# Altium schematic sheet GREEN_CIRCUITRY.SchDoc(GREEN_CIRCUITRY)
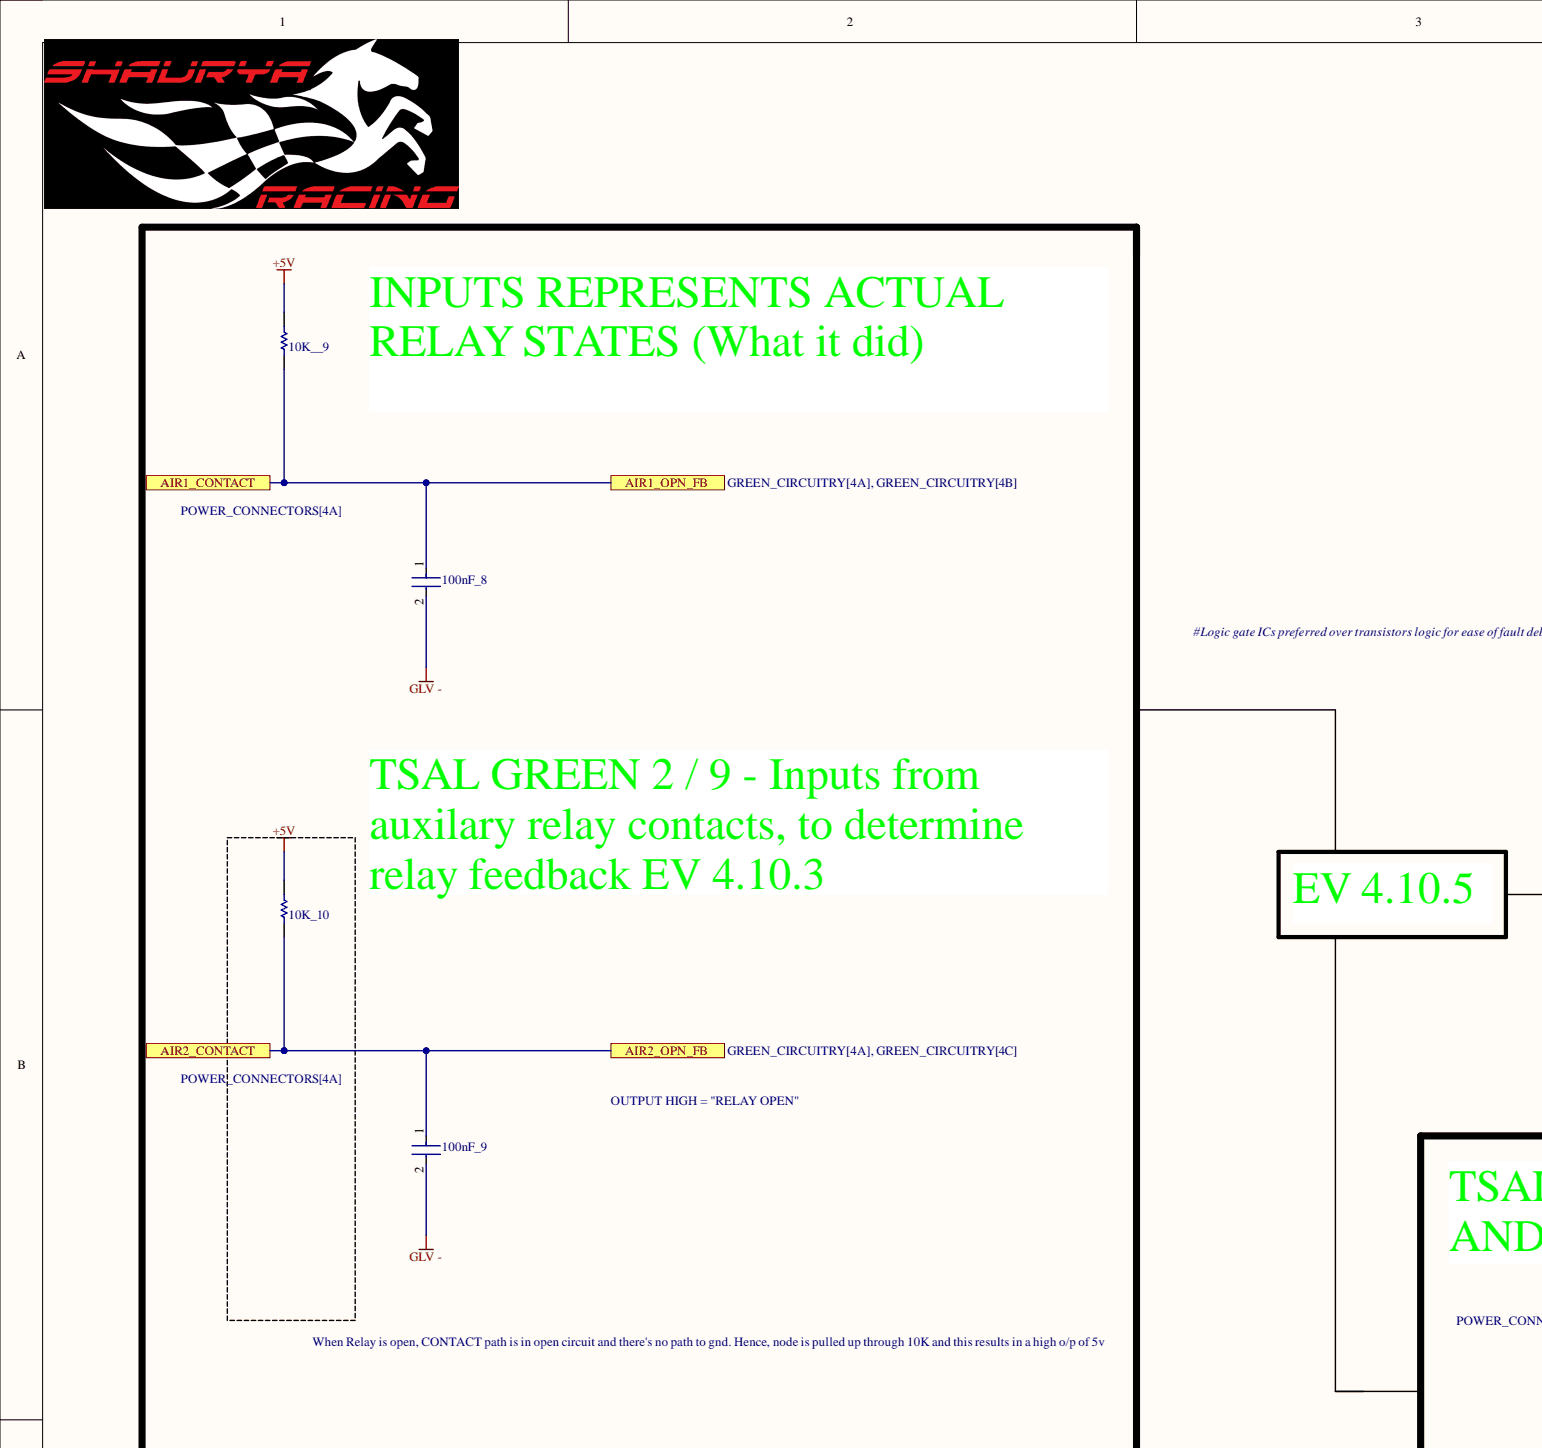
[diagram p0: GREEN_CIRCUITRY.SchDoc, top left]
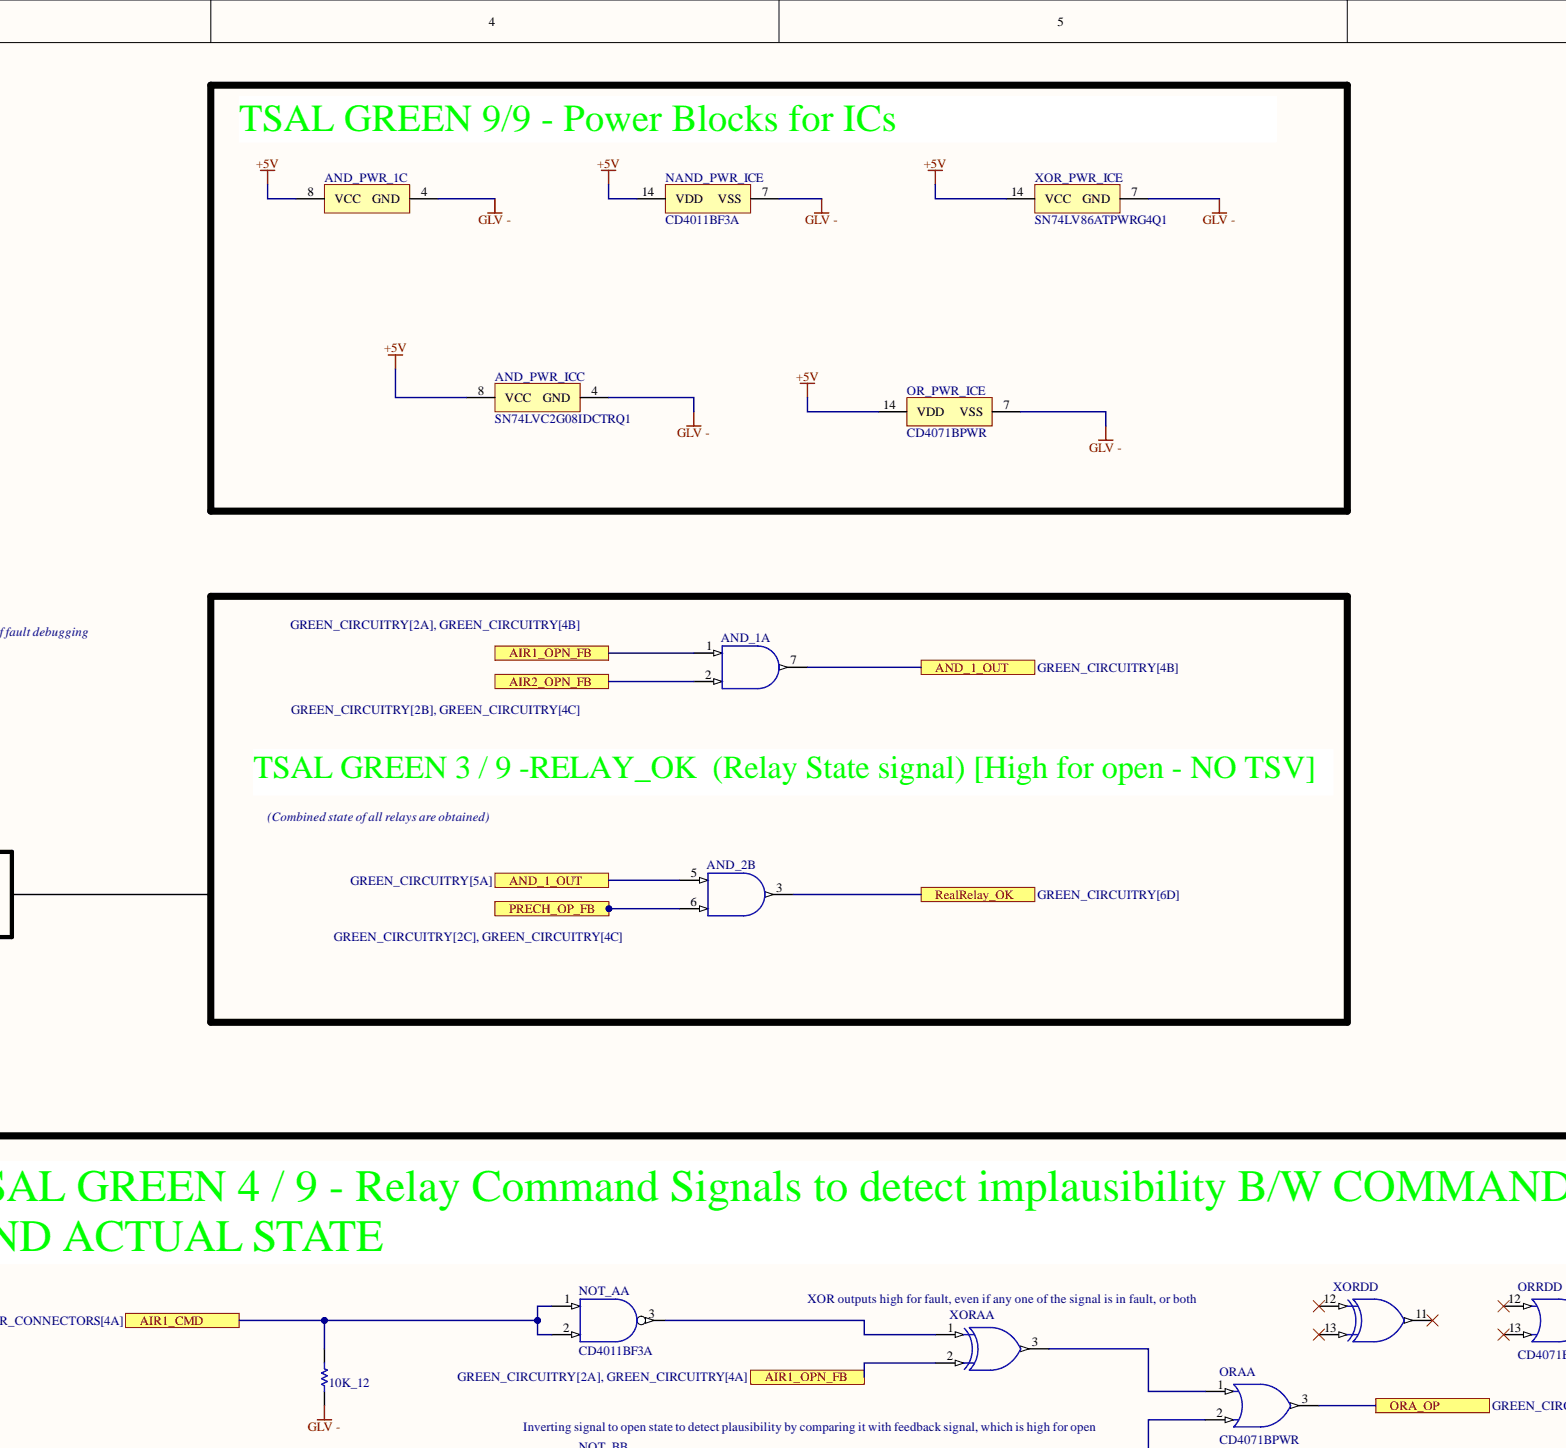
[diagram p1: GREEN_CIRCUITRY.SchDoc, top centre]
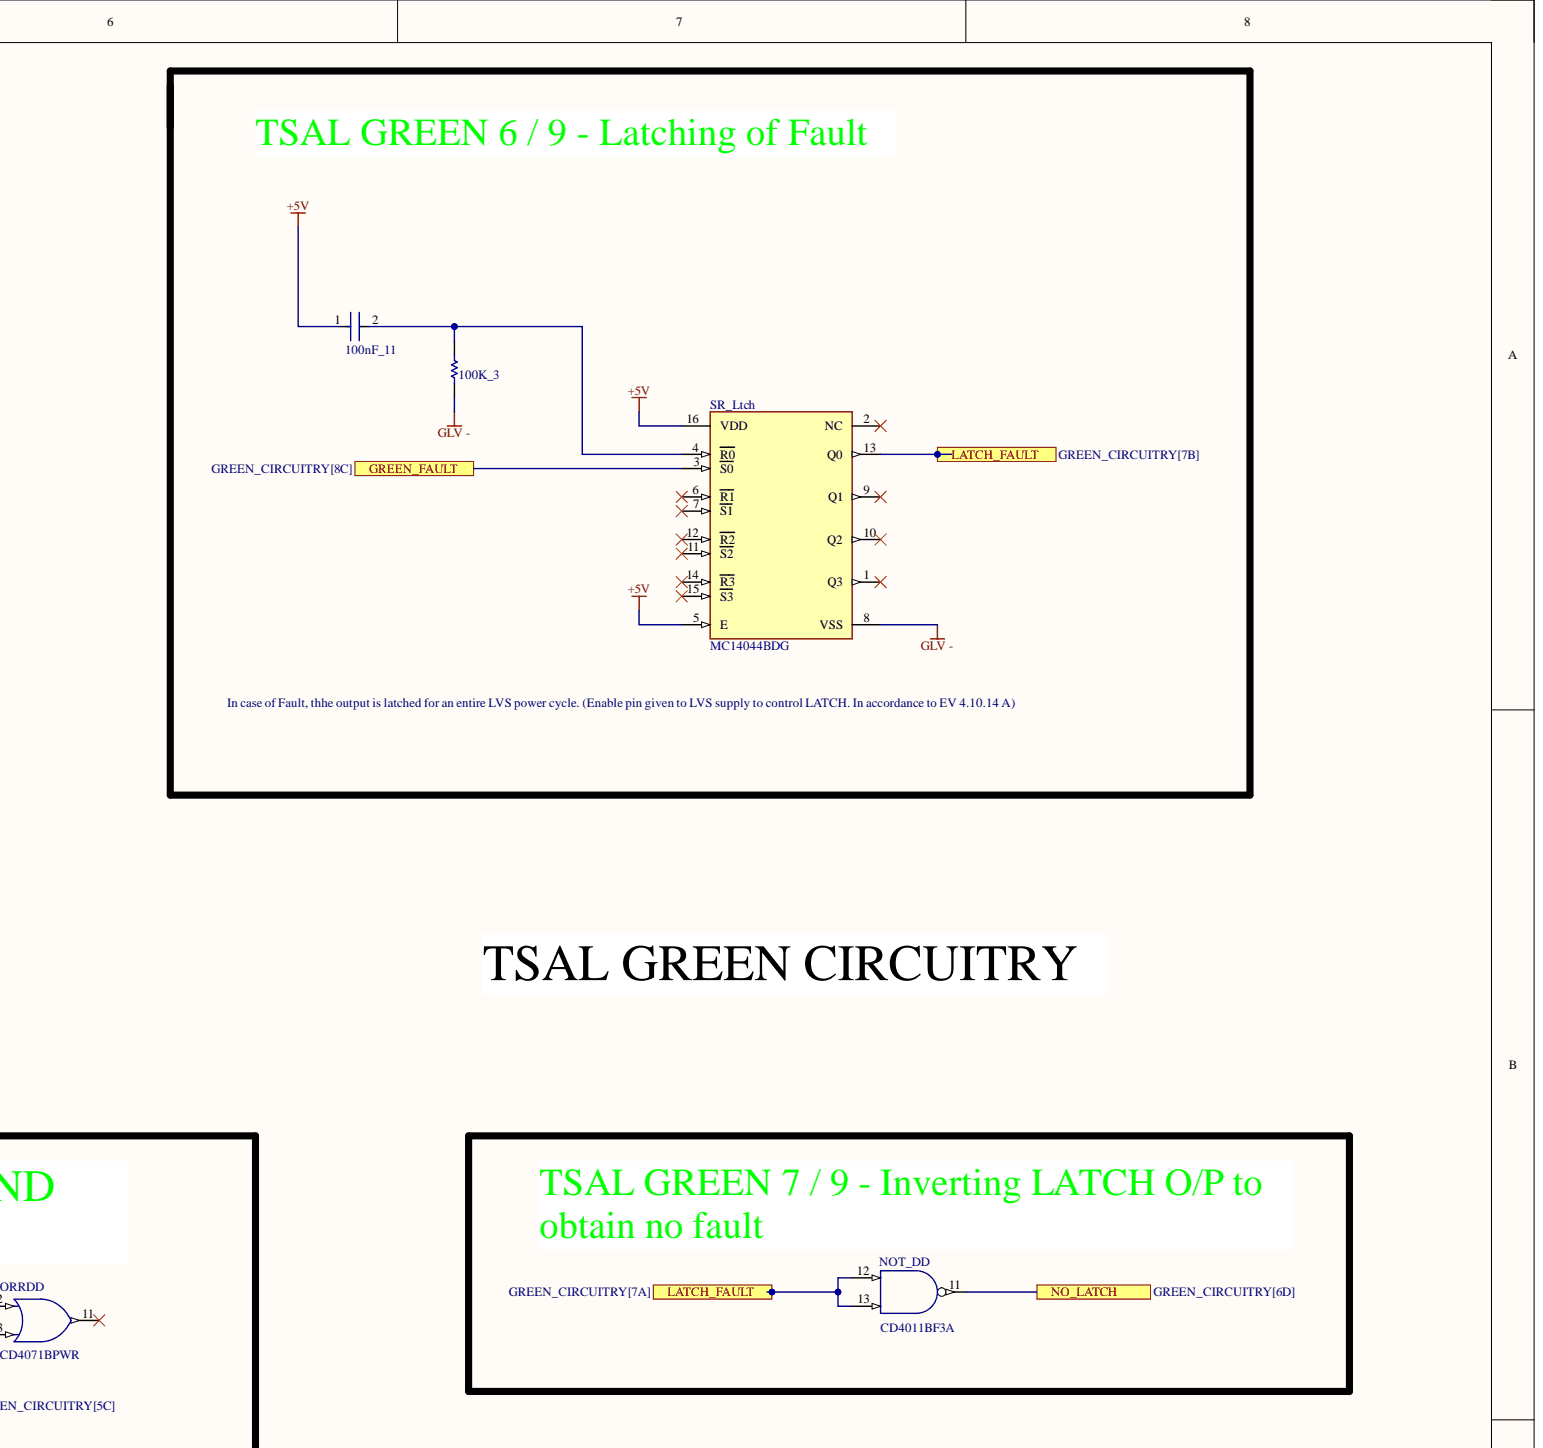
[diagram p2: GREEN_CIRCUITRY.SchDoc, top right]
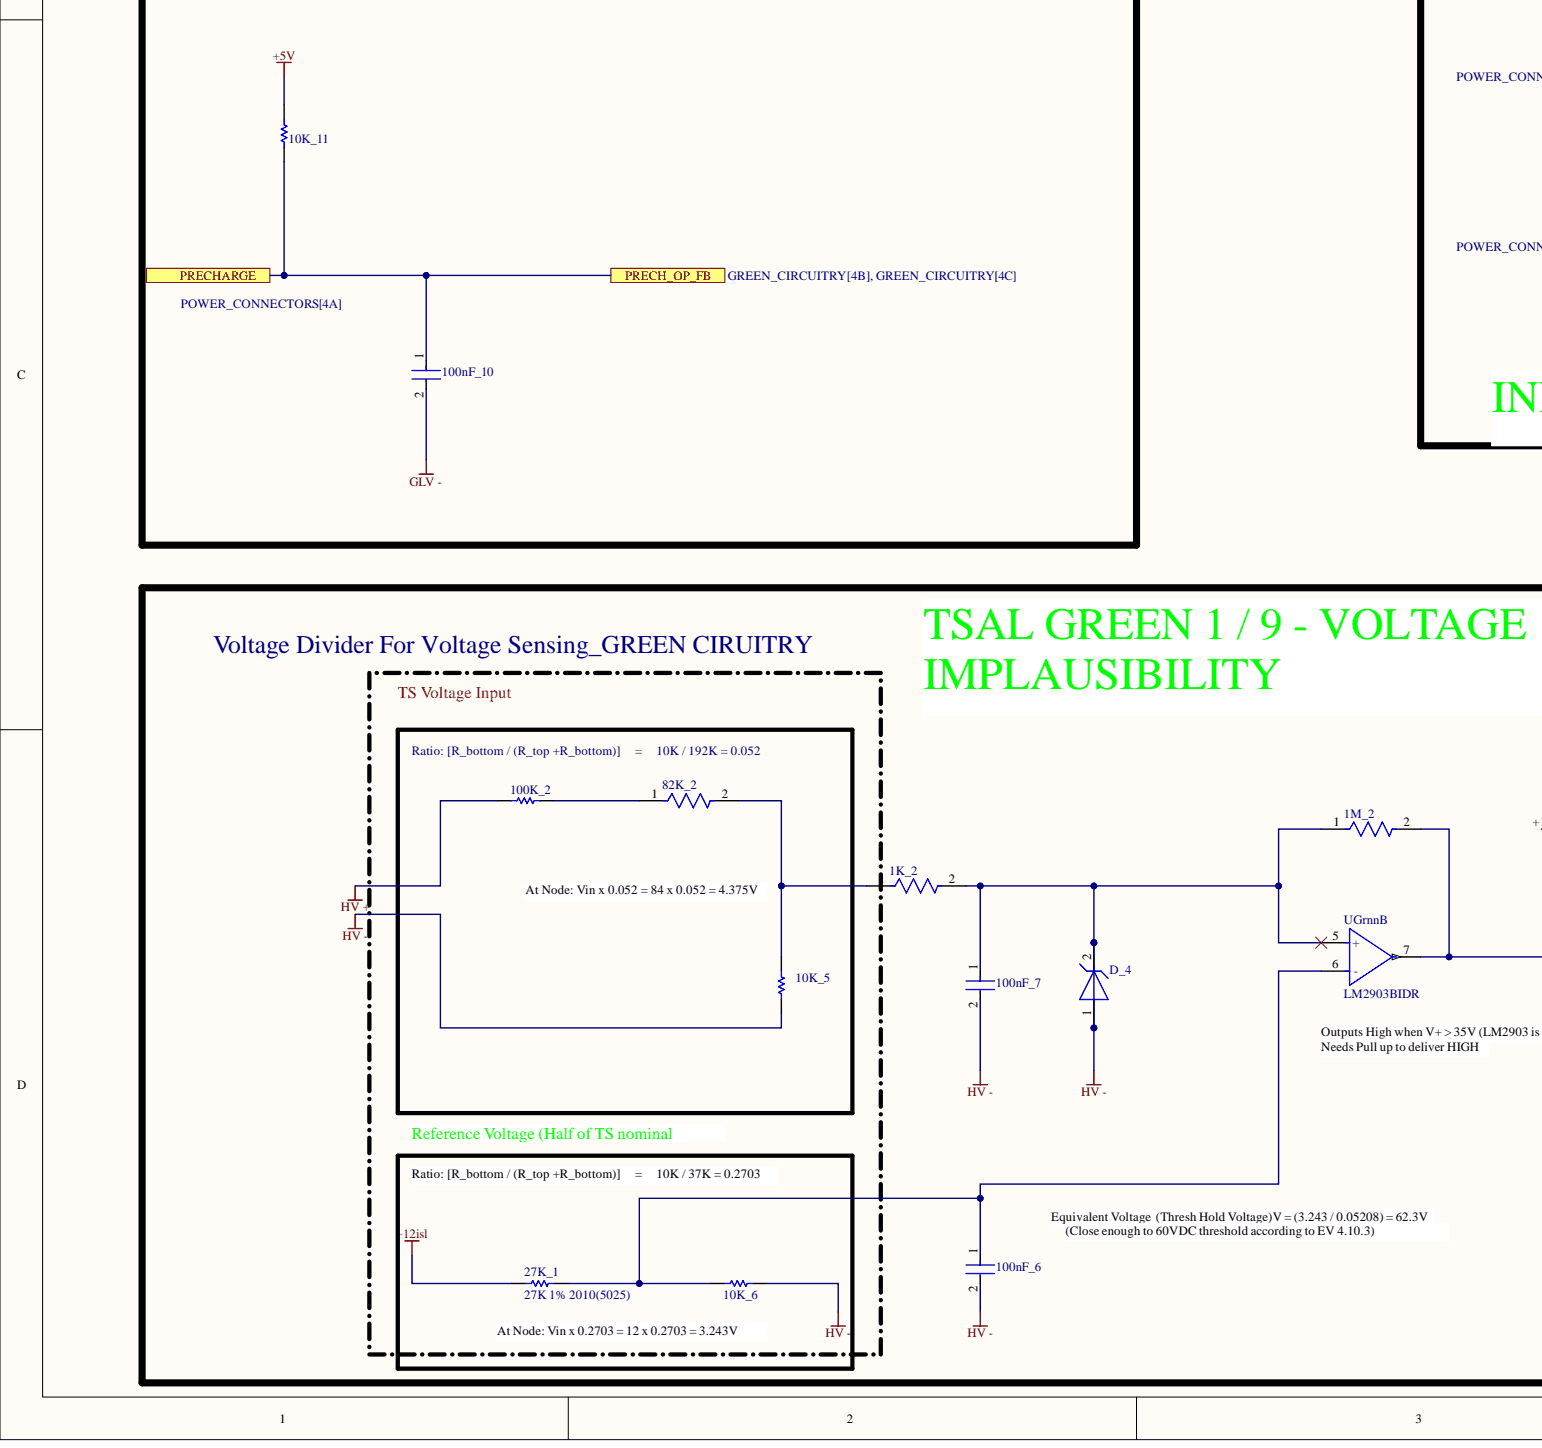
[diagram p3: GREEN_CIRCUITRY.SchDoc, bottom left]
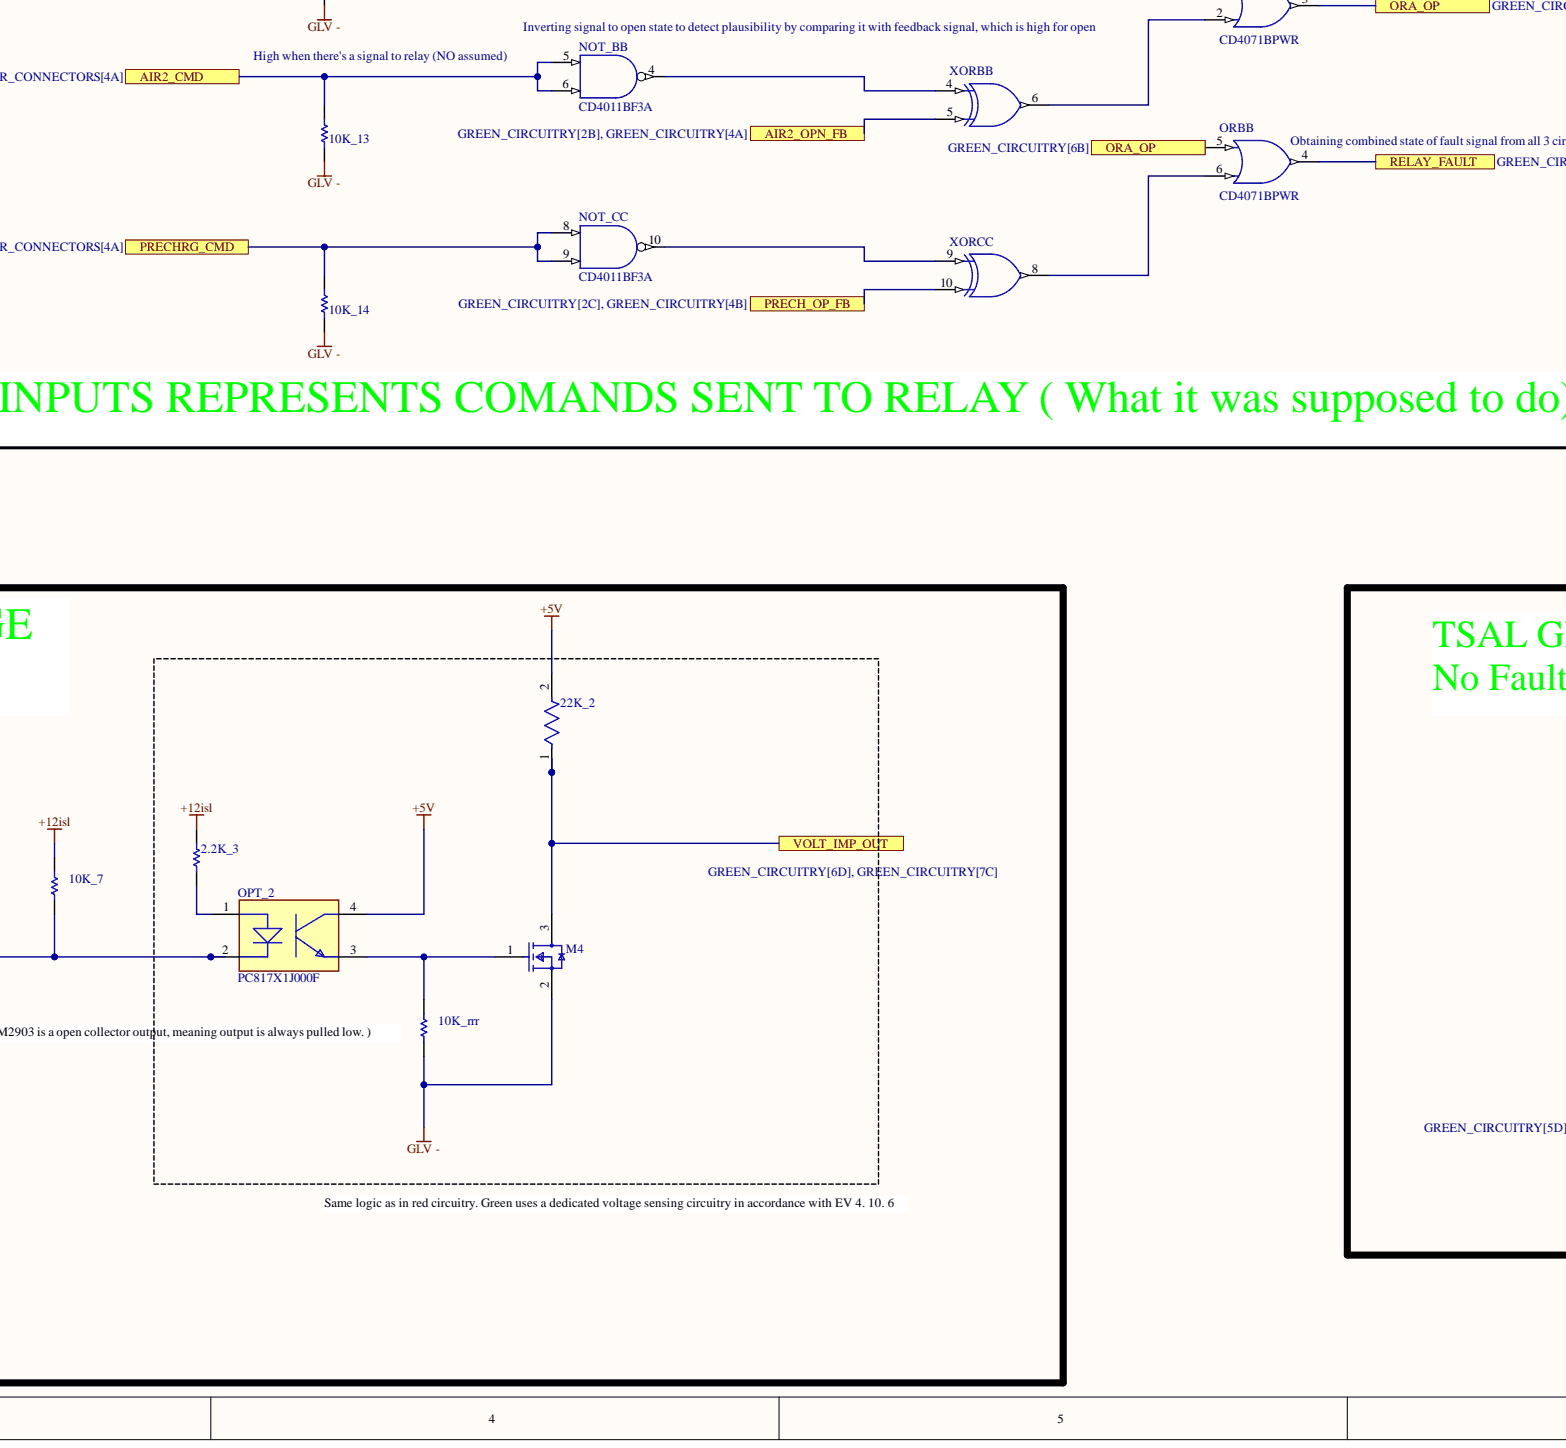
[diagram p4: GREEN_CIRCUITRY.SchDoc, bottom centre]
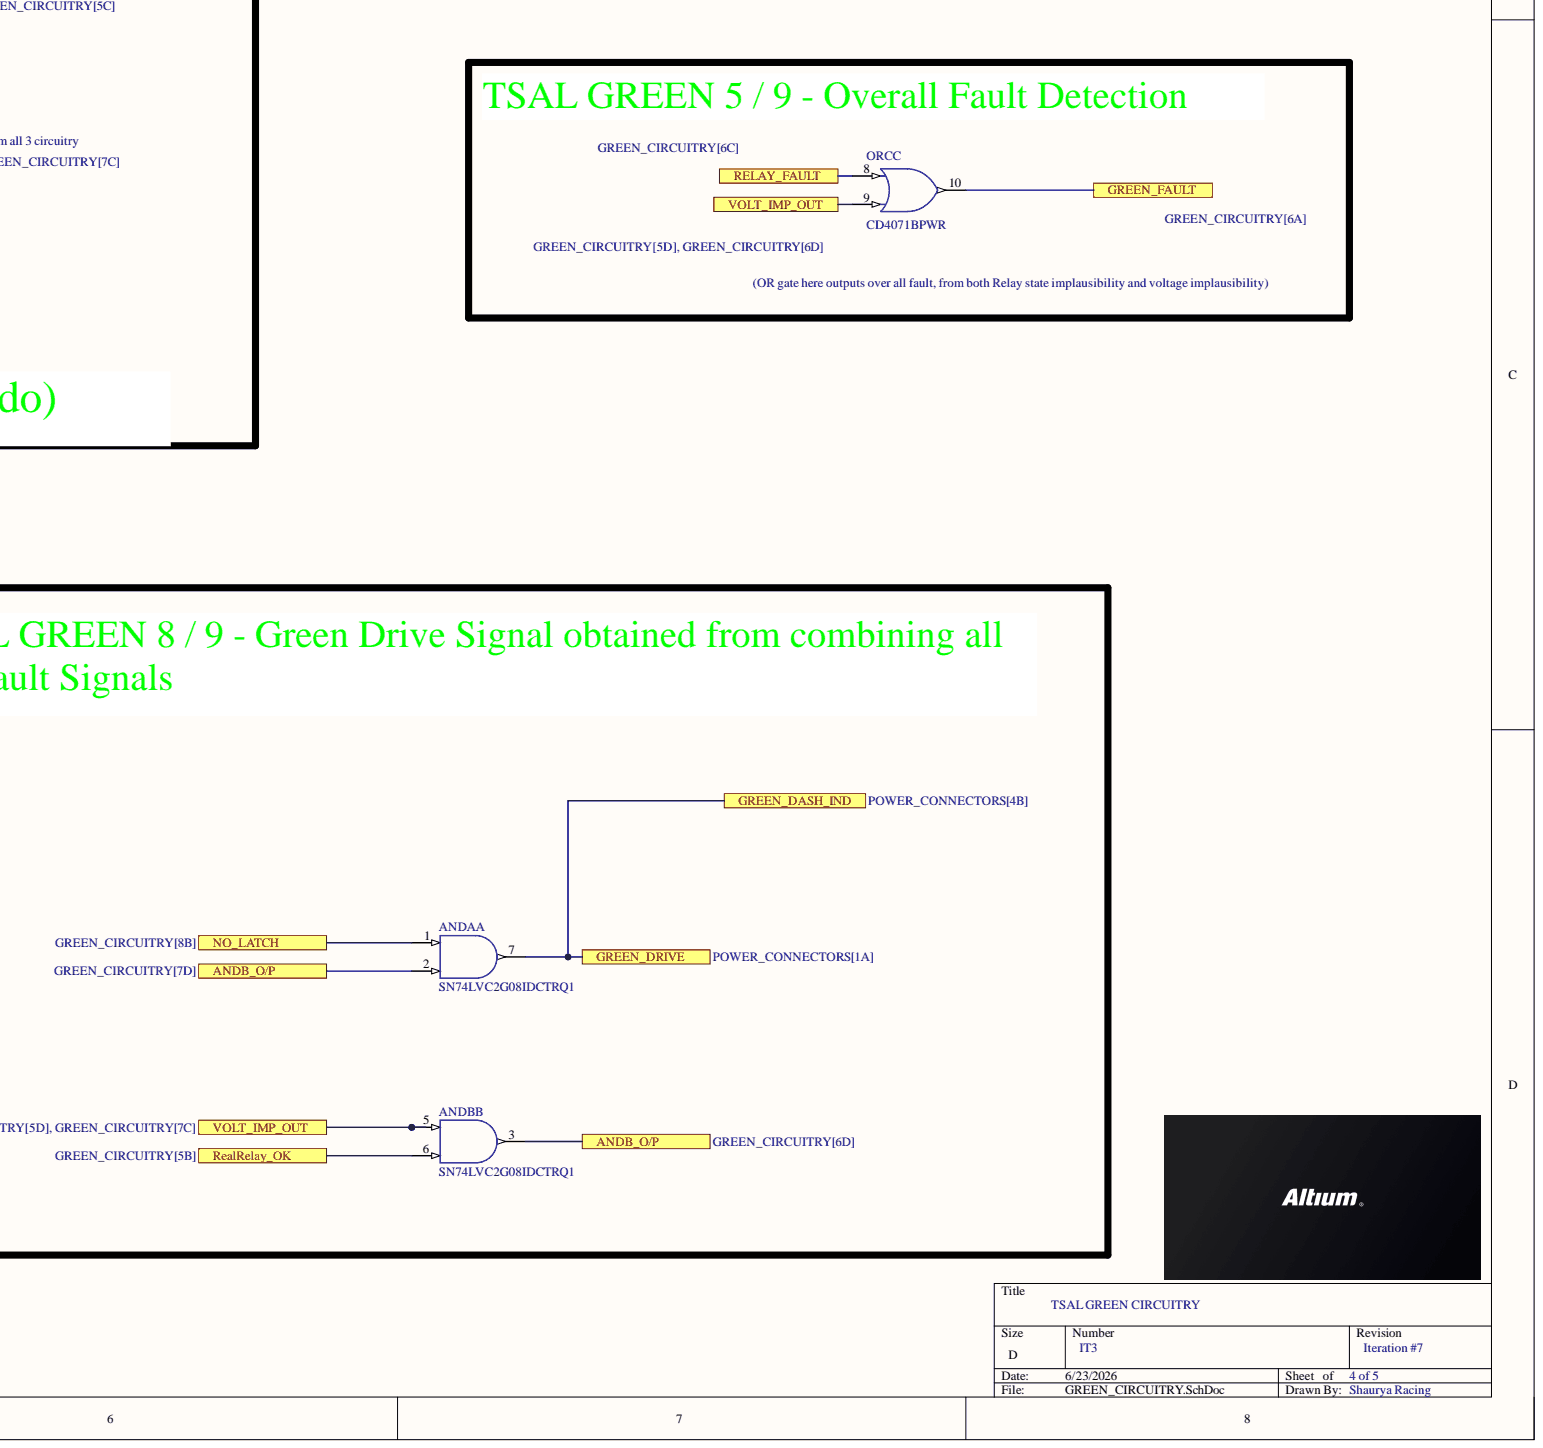
[diagram p5: GREEN_CIRCUITRY.SchDoc, bottom right]

## Parts
1K_2  CRCW08051K00JNEC  (value not drawn)
1M_2  MCU08050D1004BP500  (value not drawn)
2.2K_3  2K2 5% 0402(1005)  (value not drawn)
10K_5  TNPW121010K0BEEN  (value not drawn)
10K_6  TNPW121010K0BEEN  (value not drawn)
10K_7  TNPW121010K0BEEN  (value not drawn)
10K_10  TNPW121010K0BEEN  (value not drawn)
10K_11  TNPW121010K0BEEN  (value not drawn)
10K_12  TNPW121010K0BEEN  (value not drawn)
10K_13  TNPW121010K0BEEN  (value not drawn)
10K_14  TNPW121010K0BEEN  (value not drawn)
10K__9  TNPW121010K0BEEN  (value not drawn)
10K_rrr  TNPW121010K0BEEN  (value not drawn)
22K_2  CRCW121822K0FKEK  (value not drawn)
27K_1  27K 1% 2010(5025)
82K_2  CRCW121082K0FKEA  (value not drawn)
100K_2  TNPW0805100KDEEA  (value not drawn)
100K_3  TNPW0805100KDEEA  (value not drawn)
100nF_6  C0805C104K5RACTU  (value not drawn)
100nF_7  C0805C104K5RACTU  (value not drawn)
100nF_8  C0805C104K5RACTU  (value not drawn)
100nF_9  C0805C104K5RACTU  (value not drawn)
100nF_10  C0805C104K5RACTU  (value not drawn)
100nF_11  C0805C104K5RACTU  (value not drawn)
AND_1  SN74LVC2G08IDCTRQ1  (part A)
AND_2  SN74LVC2G08IDCTRQ1  (part B)
AND_PWR_1  SN74LVC2G08IDCTRQ1  (part C)
AND_PWR_IC  SN74LVC2G08IDCTRQ1  (part C)
ANDA  SN74LVC2G08IDCTRQ1  (part A)
ANDB  SN74LVC2G08IDCTRQ1  (part B)
D_4  BZD27C5V1P-E3-08  (value not drawn)
M4  2N7002H6327XTSA2  (value not drawn)
NAND_PWR_IC  CD4011BF3A  (part E)
NOT_A  CD4011BF3A  (part A)
NOT_B  CD4011BF3A  (part B)
NOT_C  CD4011BF3A  (part C)
NOT_D  CD4011BF3A  (part D)
OPT_2  PC817X1J000F
OR_PWR_IC  CD4071BPWR  (part E)
ORA  CD4071BPWR  (part A)
ORB  CD4071BPWR  (part B)
ORC  CD4071BPWR  (part C)
ORRD  CD4071BPWR  (part D)
SR_Ltch  MC14044BDG
UGrnn  LM2903BIDR  (part B)
XOR_PWR_IC  SN74LV86ATPWRG4Q1  (part E)
XORA  SN74LV86ATPWRG4Q1  (part A)
XORB  SN74LV86ATPWRG4Q1  (part B)
XORC  SN74LV86ATPWRG4Q1  (part C)
XORD  SN74LV86ATPWRG4Q1  (part D)

## Ports
AIR1_CMD, AIR1_CONTACT, AIR1_OPN_FB, AIR2_CMD, AIR2_CONTACT, AIR2_OPN_FB, AND_1_OUT, ANDB_O/P, GREEN_DASH_IND, GREEN_DRIVE, GREEN_FAULT, LATCH_FAULT, NO_LATCH, ORA_OP, PRECH_OP_FB, PRECHARGE, PRECHRG_CMD, RealRelay_OK, RELAY_FAULT, VOLT_IMP_OUT

## Nets
+5V: 10K_10.1 10K_11.1 10K__9.1 22K_2.2 100nF_11.1 AND_PWR_1.8(VCC) AND_PWR_IC.8(VCC) NAND_PWR_IC.14(VDD) OPT_2.4 OR_PWR_IC.14(VDD) SR_Ltch.5(E) SR_Ltch.16(VDD) XOR_PWR_IC.14(VCC)
+12isl: 2.2K_3.2 10K_7.2 27K_1.1
GLV -: 10K_12.2 10K_13.2 10K_14.2 10K_rrr.1 100K_3.1 100nF_8.2 100nF_9.2 100nF_10.2 AND_PWR_1.4(GND) AND_PWR_IC.4(GND) M4.2 NAND_PWR_IC.7(VSS) OR_PWR_IC.7(VSS) SR_Ltch.8(VSS) XOR_PWR_IC.7(GND)
HV +: 100K_2.1
HV -: 1K_2.2 1M_2.1 10K_5.1 10K_6.2 100nF_6.2 100nF_7.1 100nF_7.2 D_4.1 D_4.2 UGrnn.5(+)
AIR1_CMD: 10K_12.1 NOT_A.1 NOT_A.2 ; port AIR1_CMD
AIR1_CONTACT: 10K__9.2 100nF_8.1 AND_1.1 XORA.2 ; port AIR1_CONTACT, port AIR1_OPN_FB
AIR2_CMD: 10K_13.1 NOT_B.5 NOT_B.6 ; port AIR2_CMD
AIR2_CONTACT: 10K_10.2 100nF_9.1 AND_1.2 XORB.5 ; port AIR2_CONTACT, port AIR2_OPN_FB
AND_1_OUT: AND_1.7 AND_2.5 ; port AND_1_OUT
ANDB_O/P: ANDA.2 ANDB.3 ; port ANDB_O/P
GREEN_DASH_IND: ANDA.7 ; port GREEN_DASH_IND, port GREEN_DRIVE
GREEN_FAULT: ORC.10 SR_Ltch.3(S0) ; port GREEN_FAULT
LATCH_FAULT: NOT_D.12 NOT_D.13 SR_Ltch.13(Q0) ; port LATCH_FAULT
NO_LATCH: ANDA.1 NOT_D.11 ; port NO_LATCH
ORA_OP: ORA.3 ORB.5 ; port ORA_OP
PRECHARGE: 10K_11.2 100nF_10.1 AND_2.6 XORC.10 ; port PRECHARGE, port PRECH_OP_FB
PRECHRG_CMD: 10K_14.1 NOT_C.8 NOT_C.9 ; port PRECHRG_CMD
RealRelay_OK: AND_2.3 ANDB.6 ; port RealRelay_OK
RELAY_FAULT: ORB.4 ORC.8 ; port RELAY_FAULT
VOLT_IMP_OUT: 22K_2.1 ANDB.5 M4.3 ORC.9 ; port VOLT_IMP_OUT
Net1K_2_1: 1K_2.1 10K_5.2 82K_2.2
Net1M_2_2: 1M_2.2 10K_7.1 OPT_2.2 UGrnn.7
Net2.2K_3_1: 2.2K_3.1 OPT_2.1
Net10K_6_1: 10K_6.1 27K_1.2 100nF_6.1 UGrnn.6(-)
Net10K_rrr_2: 10K_rrr.2 M4.1 OPT_2.3
Net82K_2_1: 82K_2.1 100K_2.2
Net100K_3_2: 100K_3.2 100nF_11.2 SR_Ltch.4(R0)
NetNOT_A_3: NOT_A.3 XORA.1
NetNOT_B_4: NOT_B.4 XORB.4
NetNOT_C_10: NOT_C.10 XORC.9
NetORA_1: ORA.1 XORA.3
NetORA_2: ORA.2 XORB.6
NetORB_6: ORB.6 XORC.8

Not connected: ORRD 11 12 13; SR_Ltch 1(Q3) 2(NC) 6(R1) 7(S1) 9(Q1) 10(Q2) 11(S2) 12(R2) 14(R3) 15(S3); XORD 11 12 13

## Library data of the parts
1K_2 = CMP-02407-005778-1: RES Thick Film, 1kΩ, 5%, 0.125W, 200ppm/°C, 0805; Max Power Dissipation: 125mW; Resistance: 1kR; Case/Package: 0805; Component Kind: Standard; Composition: Thick Film; Contact Plating: Tin; Features: Automotive AEC-Q200; Height: 0.02inch; Lead Free: Lead Free; Length: 0.079inch; Library Name: Altium Content Vault; Max Operating Temperature: 155°C; Min Operating Temperature: -55°C; Number of Terminations: 2; Package Quantity: 20000; Packaging: Tape and Reel; Radiation Hardening: No; Temperature Coefficient: 200ppm/°C; Tolerance: 5%; Width: 0.049inch
1M_2 = CMP-02407-001361-1: RES 1.05K OHM 0.5% 1/5W 0805; Resistance: 1MR; Tolerance: 0.1%; Case/Package: 0805; Component Kind: Standard; Height: 450um; Lead Free: Lead Free; Length: 2mm; Library Name: Altium Content Vault; Width: 1.25mm
2.2K_3 = CMP-1011-00082-1: 2K2 0.063W 5% 0402 (1005 Metric)  SMD; Case-EIA: 0402; Case-Metric: 1005; Value: 2200.00 Ohm; Component Kind: Standard; Library Name: Altium Content Vault; Power: 0.063 W; Technology: SMT; Tolerance: 5 %
10K_5-10K_7 10K_10-10K_14 10K__9 10K_rrr = CMP-2005-00857-1: Manufacturer: Vishay Dale; Component Kind: Standard; Library Name: Altium Content Vault; Part Number: TNPW121010K0BEEN
22K_2 = CMP-1016-00638-2: RES Thick Film, 22kΩ, 1%, 1W, 100ppm/°C, 1218; Resistance: 22000R; Component Kind: Standard; Lead Free: Lead Free; Library Name: Altium Content Vault; Power Rating: 1W; Tolerance: 1%
27K_1 = CMP-1017-00670-1: 27K 0.75W 1% 2010 (5025 Metric)  SMD; Case-EIA: 2010; Case-Metric: 5025; Value: 27000.00 Ohm; Component Kind: Standard; Library Name: Altium Content Vault; Power: 0.75 W; Technology: SMT; Tolerance: 1 %
82K_2 = CMP-1015-00726-2: RES SMD 205K OHM 1% 1/2W 2203; Resistance: 82kR; Temperature Coefficient: 100ppm/°C; Voltage Rating (DC): 200V; Case/Package: 1210; Component Kind: Standard; Composition: Thick Film; Contact Plating: Tin; Height: 550um; Lead Free: Lead Free; Length: 3.2mm; Library Name: Altium Content Vault; Max Operating Temperature: 155°C; Min Operating Temperature: -55°C; Packaging: Tape and Reel; Radiation Hardening: No; Tolerance: 1%; Width: 2.5mm
100K_2 100K_3 = CMP-2001-04708-1: Manufacturer: Vishay Dale; Component Kind: Standard; Library Name: Altium Content Vault; Part Number: TNPW0805100KDEEA
100nF_6-100nF_11 = CMP-2007-00279-2: CAP CER 0.1UF 50V X7R 0805; Manufacturer: KEMET; Capacitance: 0.10 μF; Temperature Coefficient: 30ppm/°C; Tolerance: 0.1; Value: 0.10 μF; Automotive: No; Category: Cap; Component Kind: Standard; ComponentLink2Description: Datasheet; ComponentLink2URL: https://content.kemet.com/datasheets/KEM_C1003_C0G_SMD.pdf; Device Class L1: Passive Components; Device Class L2: Capacitors; Device Class L3: Ceramic Capacitors; Digikey Description: CAP CER 0.1UF 50V X7R 0805; Height: 0.88mm; Ipc Land Pattern Name: CAPC200125X78; Lead Free: Yes; Library Name: Altium Content Vault; Manufacturer Part Number: C0805C104K5RACTU; Material: Ceramic; Mouser Description: Cap Ceramic 0.1uF 50V X7R 10% SMD 2.0 x 1.2 mm 125 C Paper T/R; Octopart: https://octopart.com/c0805c104k5ractu-kemet-143926; Package: C0805C-DN; RoHS: TRUE; Temperature: -55°C to +125°C; Temperature Characteristic: X7R; Temperature Range High: +125°C; Temperature Range Low: -55°C; Voltage Rating: 50 V
AND_1 AND_2 AND_PWR_1 AND_PWR_IC ANDA ANDB = CMP-0859-00543-3: Automotive Catalog Dual 2-Input Positive-AND Gate, DCT0008A, LARGE T&R; Generic Part Number: SN74LVC2G08-Q1; Manufacturer: Texas Instruments; 3-State Output: No; Bits(#): 2; F @ Nom Voltage(Max)(Mhz): 150; ICC @ Nom Voltage(Max)(mA): 0.01; Input Type: CMOS/TTL; Logic: True; Output Drive (IOL/IOH)(Max)(mA): 32/-32; Output Type: CMOS; Technology Family: LVC; tpd @ Nom Voltage(Max)(ns): 9,5.1,4.7,3.8; VCC(Max)(V): 5.5; VCC(Min)(V): 1.65; Voltage(Nom)(V): 1.8,2.5,3.3,5; Component Kind: Standard; DatasheetVersion: SCES557D; Library Name: Altium Content Vault; Operating Temperature Range(C): -40 to 85; PackageReference: DCT0008A; Rating: Automotive; Schmitt Trigger: No; Sub-Family: AND Gate
D_4 = CMP-02541-000027-1: DIODE ZENER 5.1V 800MW DO219AB; Element Configuration: Single; ESD Protection: Yes; Forward Voltage: 1.2V; Impedance: 6R; Max Reverse Current: 5uA; Test Current: 100mA; Tolerance: 6%; Voltage Tolerance: 5%; Breakdown Voltage: 5.1V; Case/Package: DO-219AB; Component Kind: Standard; Contact Plating: Tin; Height: 1.08mm; Lead Free: Lead Free; Length: 2.9mm; Library Name: Altium Content Vault; Max Operating Temperature: 175°C; Max Power Dissipation: 800mW; Max Reverse Leakage Current: 5uA; Min Operating Temperature: -65°C; Mount: Surface Mount; Number of Pins: 2; Package Quantity: 3000; Packaging: Tape and Reel; RoHS Compliant: Yes; Series: Automotive, AEC-Q101, BZD27C; Width: 1.9mm; Zener Current: 5uA; Zener Voltage: 5.1V
M4 = CMP-32901-000180-1: MOSFET N-CH 60V 0.3A SOT23; Continuous Drain Current (ID): 300mA; Gate to Source Voltage (Vgs): 20V; Input Capacitance: 20pF; Max Power Dissipation: 500mW; Rds On Max: 3R; Rise Time: 3.3ns; Case/Package: SOT-23; Component Kind: Standard; Drain to Source Voltage (Vdss): 60V; Halogen Free: Halogen Free; Lead Free: Lead Free; Library Name: Altium Content Vault; Max Dual Supply Voltage: 60V; Max Operating Temperature: 150°C; Min Operating Temperature: -55°C; Mount: Surface Mount; Number of Pins: 3; Packaging: Tape and Reel
NAND_PWR_IC NOT_A NOT_B NOT_C NOT_D = CMP-1627-00341-2: CMOS Quad 2-Input NAND Gate, J0014A, TUBE; Generic Part Number: CD4011B-MIL; Manufacturer: Texas Instruments; Bits(#): 4; F @ Nom Voltage(Max)(Mhz): 8; ICC @ Nom Voltage(Max)(mA): 0.015; Output Drive (IOL/IOH)(Max)(mA): 1.5/-1.5; Rating: Military; Schmitt Trigger: No; Technology Family: CD4000; VCC(Max)(V): 18; VCC(Min)(V): 3; Voltage(Nom)(V): 10; Component Kind: Standard; DatasheetVersion: SCHS021D; Input Type: CMOS; Library Name: Altium Content Vault; Operating Temperature Range(C): -55 to 125; Output Type: CMOS; PackageReference: J0014A
OPT_2 = CMP-65434-000003-1: OPTOISOLATOR 5KV TRANS 4DIP; Collector Emitter Breakdown Voltage: 80V; Collector Emitter Saturation Voltage: 200mV; Current Transfer Ratio: 600%; Fall Time: 18000ns; Forward Current: 50mA; Forward Voltage: 1.4V; Input Current: 20mA; Max Power Dissipation: 200mW; Number of Channels: 1; Reverse Breakdown Voltage: 6V; Case/Package: DIP; Collector Emitter Voltage (VCEO): 80V; Component Kind: Standard; Isolation Voltage: 5kV; Library Name: Altium Content Vault; Max Collector Current: 50mA; Max Input Current: 50mA; Max Operating Temperature: 100°C; Min Operating Temperature: -30°C; Mount: Through Hole; Number of Elements: 1; Number of Pins: 4; Output Current per Channel: 50mA; Output Voltage: 80V; Package Quantity: 2000; Packaging: Sleeve; Power Dissipation: 200mW; Radiation Hardening: No; Rise Time: 18000ns
OR_PWR_IC ORA ORB ORC ORRD = CMP-1573-00201-3: CMOS Quad 2-Input OR Gate, PW0014A, LARGE T&R; Manufacturer: Texas Instruments; Bits(#): 4; F @ Nom Voltage(Max)(Mhz): 8; ICC @ Nom Voltage(Max)(mA): 0.015; Output Drive (IOL/IOH)(Max)(mA): 1.5/-1.5; Rating: Military; tpd @ Nom Voltage(Max)(ns): 120; VCC(Max)(V): 18; VCC(Min)(V): 3; Voltage(Nom)(V): 10; Code_JEDEC: MO-153; Component Kind: Standard; DatasheetVersion: SCHS056D; Generic Part Number: CD4071B; Input Type: CMOS; Library Name: Altium Content Vault; Operating Temperature Range(C): -55 to 125; Output Type: CMOS; PackageReference: PW0014A; PackageVersion: http://www.ti.com/lit/ml/mpds360/mpds360.pdf
SR_Ltch = CMP-00278-00853159-1: MC14044BDG 4044 Tube 4000B ACTIVE (Last Updated: 5days ago) latch circuit 350ns 8.8mA 8.8mA 175ns 1.5mm; NexarPartId: 853159; High Level Output Current: -4.2mA; Independent Circuits: 4; Low Level Output Current: 4.2mA; Max Supply Voltage: 18V; Min Supply Voltage: 3V; Number of Elements: 1; Number of Input Lines: 8; Number of Inputs: 2; Polarity: Non-Inverting; Quiescent Current: 6nA; Turn-On Delay Time: 60ns; Ambient Temperature Range High: 125°C; Case/Package: SOIC; Component Kind: Standard; Halogen Free: Halogen Free; Height: 1.5mm; Lead Free: Lead Free; Length: 10mm; Library Name: Altium Content Vault; Lifecycle Status: Production; Logic Function: Latch; Manufacturer Lifecycle Status: ACTIVE; Max Operating Temperature: 125°C; Min Operating Temperature: -55°C; Mount: Surface Mount; Number of Bits: 4; Number of Channels: 4; Number of Circuits: 4; Number of Gates: 4; Number of Output Lines: 4; Number of Outputs: 1; Number of Pins: 16; Output Current: 8.8mA; Propagation Delay: 175ns; Radiation Hardening: No; REACH SVHC: No SVHC; RoHS: Compliant; Schedule B: 8542390000, 8542390000; Width: 4mm
UGrnn = CMP-04896-000007-1: 36V JI.3 DUAL COMPARATOR; Manufacturer: Texas Instruments; Input Bias Current: -0.0035uA; Input Offset Voltage: 4mV; Max Junction Temp: +150°C; Min Supply Voltage: 3V; Nominal Supply Current: 400-550uA; Number Of Channels: 2; Output Current Per Channel: 25mA; Response Time: 1us; Standoff Height: 0.11mm; Ambient Temperature Range High: +125°C; Ambient Temperature Range Low: -40°C; Automotive: No; Category: IC; Component Kind: Standard; ComponentLink2Description: Datasheet; ComponentLink2URL: http://www.ti.com/lit/ds/symlink/lm2903b.pdf; Device Class L1: Integrated Circuits (ICs); Device Class L2: Linear ICs; Device Class L3: Comparators; Digikey Description: 36V JI.3 DUAL COMPARATOR; Height: 1.75mm; Lead Free: Yes; Library Name: Altium Content Vault; Manufacturer Part Number: LM2903BIDR; Max Supply Voltage: 36V; Octopart: https://octopart.com/lm2903bidr-texas+instruments-104977165; Package: D0008A; RoHS: TRUE; Temperature: -40°C to +125°C; Temperature Range High: +125°C; Temperature Range Low: -40°C
XOR_PWR_IC XORA XORB XORC XORD = CMP-0778-00153-3: Automotive Catalog Quadruple 2-Input Exclusive-OR Gates, PW0014A, LARGE T&R; Generic Part Number: SN74LV86A-Q1; Manufacturer: Texas Instruments; Bits(#): 4; F @ Nom Voltage(Max)(Mhz): 70; ICC @ Nom Voltage(Max)(mA): 0.02; Input Type: TTL; Output Drive (IOL/IOH)(Max)(mA): 50/-50; Schmitt Trigger: No; Technology Family: LV-A; tpd @ Nom Voltage(Max)(ns): 26.5,16.5,10; VCC(Max)(V): 5.5; VCC(Min)(V): 2; Voltage(Nom)(V): 2.5,3.3,5; Component Kind: Standard; DatasheetVersion: SCLS534C; Library Name: Altium Content Vault; Operating Temperature Range(C): -40 to 105; Output Type: TTL; PackageReference: PW0014A; Rating: Automotive
